# Altium schematic sheet Digital Output.SchDoc
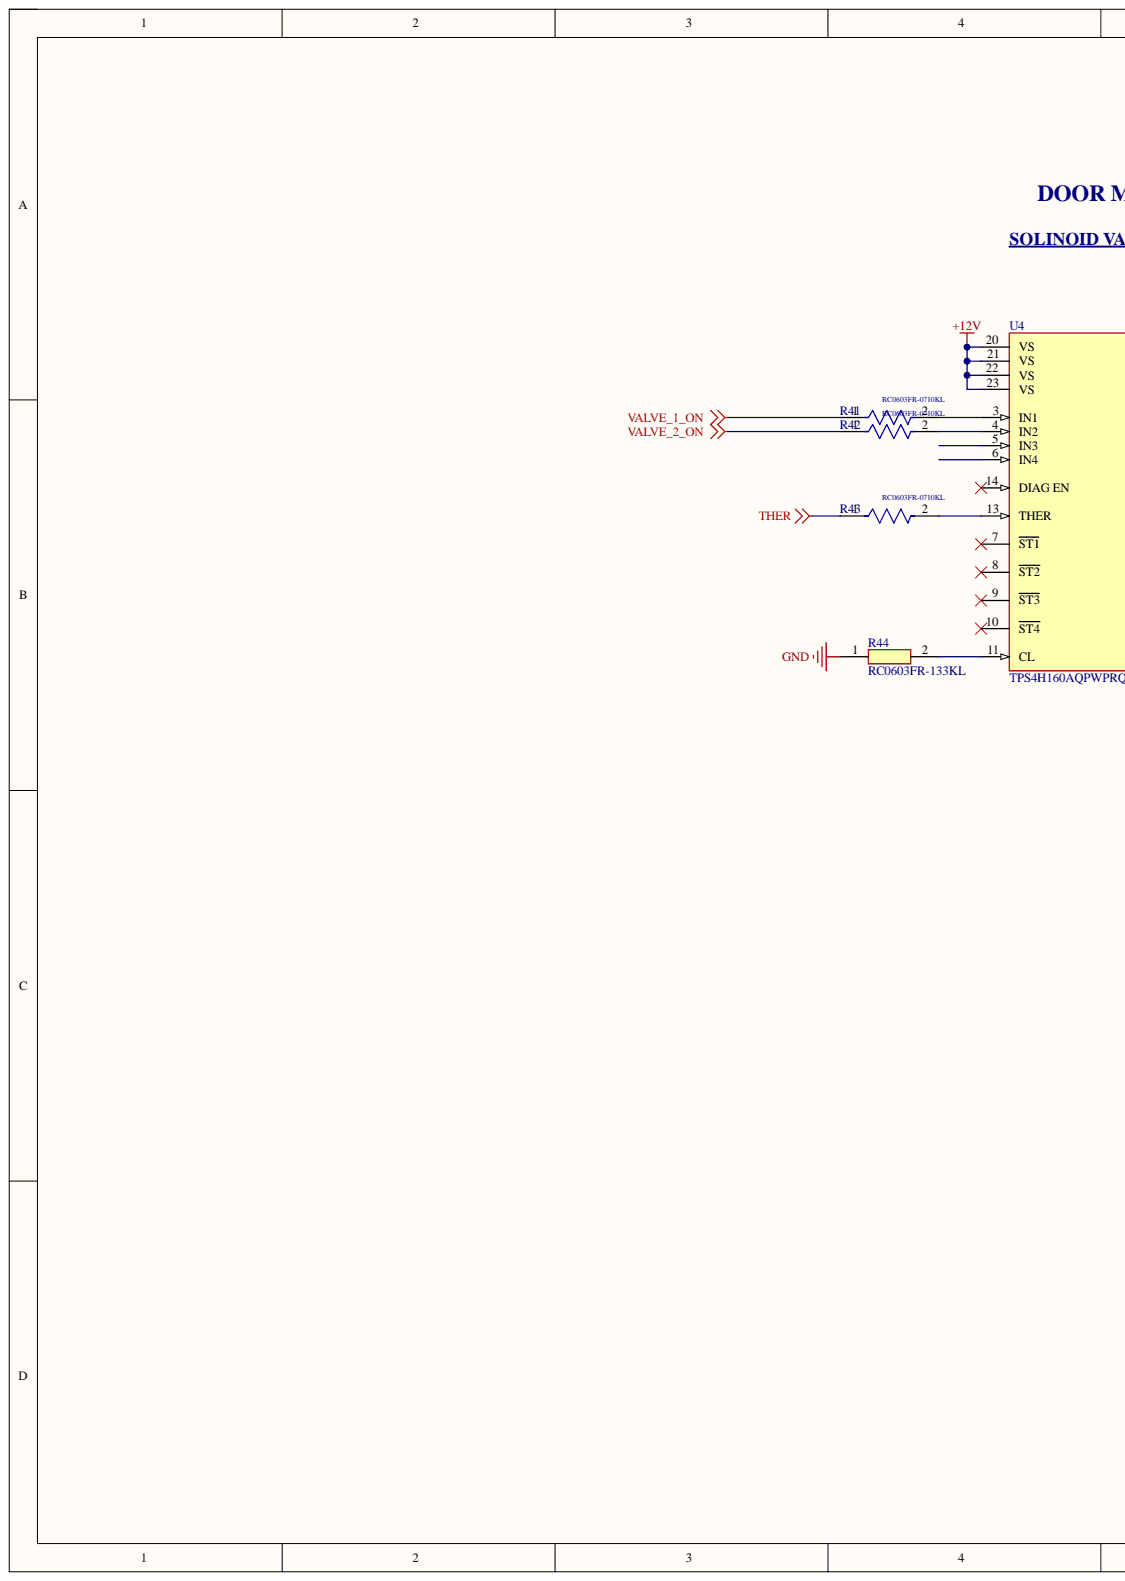
[diagram p0: Digital Output.SchDoc, left]
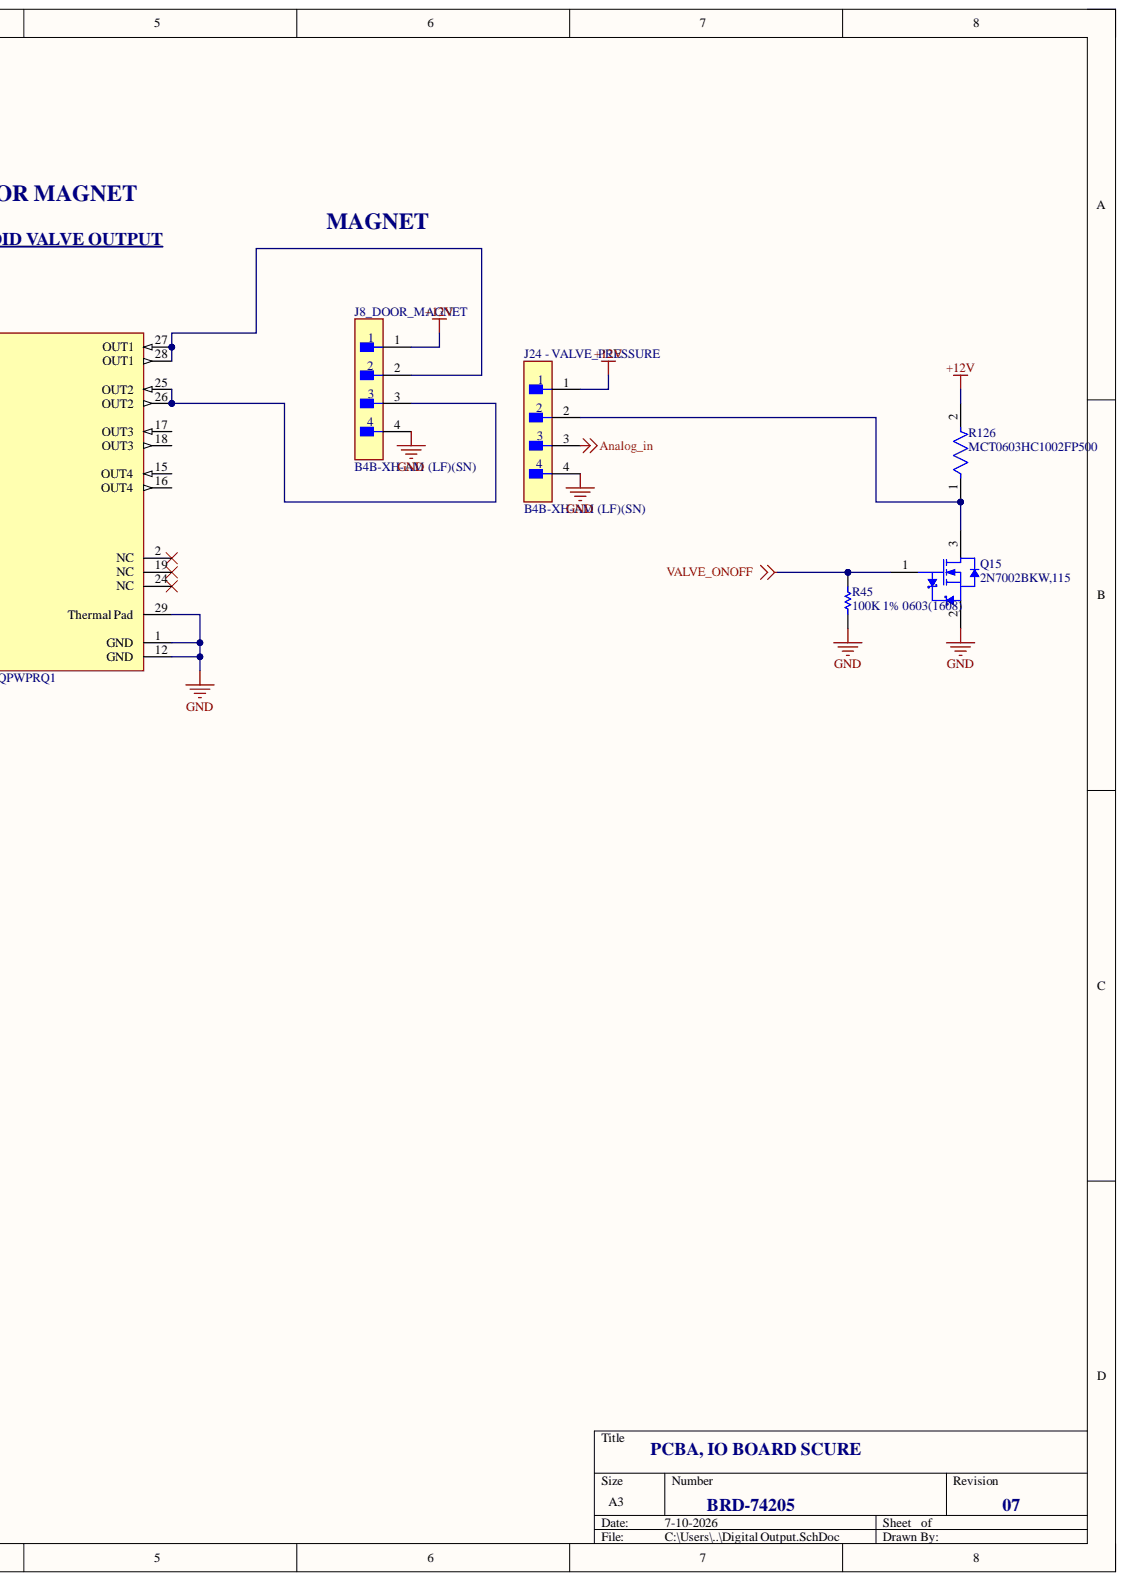
[diagram p1: Digital Output.SchDoc, right]

## Parts
J8_DOOR_MAGNET  B4B-XH-AM (LF)(SN)  [FP-B4B-XH-AM_LF_SN-MFG]
J24_-_VALVE_PRESSURE  B4B-XH-AM (LF)(SN)  [FP-B4B-XH-AM_LF_SN-MFG]
Q15  2N7002BKW,115  [NXP-SOT323_N]
R41  RC0603FR-0710KL  [FP-RC0603-0_55-IPC_C]
R42  RC0603FR-0710KL  [FP-RC0603-0_55-IPC_C]
R43  RC0603FR-0710KL  [FP-RC0603-0_55-IPC_C]
R44  RC0603FR-133KL  [RESC1608X55N]
R45  100K 1% 0603(1608)  [RESC0603(1608)_L]
R126  MCT0603HC1002FP500  [FP-MCT0603-IPC_B]
U4  TPS4H160AQPWPRQ1  [FP-PWP0028C-MFG]

## Nets
+12V: J8_DOOR_MAGNET.1 J24_-_VALVE_PRESSURE.1 R126.2 U4.20(VS) U4.21(VS) U4.22(VS) U4.23(VS)
Analog_in: J24_-_VALVE_PRESSURE.3
GND: J8_DOOR_MAGNET.4 J24_-_VALVE_PRESSURE.4 Q15.2 R44.1 R45.1 U4.1(GND) U4.12(GND) U4.29(Thermal_Pad)
THER: R43.1
VALVE_1_ON: R41.1
VALVE_2_ON: R42.1
VALVE_ONOFF: Q15.1 R45.2
NetJ8_DOOR_MAGNET_2: J8_DOOR_MAGNET.2 U4.27(OUT1) U4.28(OUT1)
NetJ8_DOOR_MAGNET_3: J8_DOOR_MAGNET.3 U4.25(OUT2) U4.26(OUT2)
NetJ24 - VALVE_PRESSURE_2: J24_-_VALVE_PRESSURE.2 Q15.3 R126.1
NetR41_2: R41.2 U4.3(IN1)
NetR42_2: R42.2 U4.4(IN2)
NetR43_2: R43.2 U4.13(THER)
NetR44_2: R44.2 U4.11(CL)

Not connected: U4 2(NC) 5(IN3) 6(IN4) 7(ST1) 8(ST2) 9(ST3) 10(ST4) 14(DIAG_EN) 15(OUT4) 16(OUT4) 17(OUT3) 18(OUT3) 19(NC) 24(NC)

## Library data of the parts
J8_DOOR_MAGNET J24_-_VALVE_PRESSURE = CMP-17439-000117-1: CONN HEADER VERT 4POS 2.5MM; Contact Resistance: 20mR; Gender: Male; Mated Stacking Heights: 9.8mm; Max Current Rating: 3A; Max Voltage Rating (AC): 250V; Number of Positions: 4; Color: Green; Component Kind: Standard; Connector Type: Header; Contact Finish - Mating: TIN; Contact Material: Brass; Contact Plating: Copper; Contact Shape: Square; Contact Type: Male Pin; Depth: 5.75mm; Fastening Type: Detent; Flammability Rating: UL94 V-0; Housing Color: White; Housing Material: Polyamide; Insulation Color: Natural; Insulation Material: Nylon; Lead Free: Lead Free; Length: 12.4mm; Library Name: Altium Content Vault; Max Operating Temperature: 85°C; Min Operating Temperature: -25°C; Mount: Through Hole; Number of Pins: 4; Number of Rows: 1; Orientation: Straight; Packaging: Bulk; Pitch: 2.5mm; Series: XH; Termination: Solder; Voltage Rating: 250V
Q15 = CMP-1259-00106-1: N-Channel Trench MOSFET, 60 V, 0.31 A, -55 to 150 degC, 3-Pin SOT323, Tape and Reel; Manufacturer: NXP Semiconductors; Automotive Qualified: Y; Channel Type: N; ID [max] (A): 0.31; IDM [max] (A): 1.2; Packing: Tape & Reel; VDS [max] (V): 60; VGSth [typ] (V): 1.6; Code_JEITA: SC-70; Component Kind: Standard; ComponentLink1Description: Manufacturer URL; ComponentLink1URL: http://www.nxp.com/; ComponentLink2Description: Datasheet; ComponentLink2URL: http://www.nxp.com/documents/data_sheet/2N7002BKW.pdf; ComponentLink3Description: Package Specification; ComponentLink3URL: http://www.nxp.com/documents/outline_drawing/sot323_po.pdf; DatasheetVersion: Rev. 1; Library Name: Altium Content Vault; Mounting Technology: Surface Mount; PackageDescription: 3-Pin Small Outline Transistor Package, Body 2 x 1.25 mm, Pitch 0.65 mm; PackageReference: SOT323; PackageVersion: 06-03-16, 03/2006; PartNumber: 2N7002BKW,115
R41-R43 = CMP-2100-03664-2: RES 10K OHM 1% 1/10W 0603; Max Power Dissipation: 100mW; Resistance: 10kR; Temperature Coefficient: 100ppm/°C; Voltage Rating: 75V; Voltage Rating (DC): 50V; Case Code (Imperial): 0603; Case Code (Metric): 1608; Case/Package: 0603; Component Kind: Standard; Construction: Rectangular; Depth: 0.8mm; Features: Moisture Resistant; Height: 0.45mm; Lead Free: Lead Free; Lead Pitch: 0.3mm; Length: 1.6mm; Library Name: Altium Content Vault; Max Operating Temperature: 155°C; Military Standard: Not; Min Operating Temperature: -55°C; Number of Pins: 2; Number of Terminations: 2; Packaging: Tape and Reel; Power Rating: 100mW; Resistance Tolerance: 1%; Resistor Type: Thick Film; RoHS Compliant: Yes; Series: RC; Size Code: 0603; Termination: SMD/SMT; Tolerance: 1%; Weight: 7.1E-05oz; Width: 0.8mm
R44 = RC0603FR-133KL: Resistor; Manufacturer_Name: KEMET; Component Kind: Standard; Datasheet Link: http://www.yageo.com/documents/recent/PYu-RC0603_51_RoHS_L_v5.pdf; Height: 0.55mm; Library Name: SamacSys.SchLib; Manufacturer_Part_Number: RC0603FR-133KL; Mouser Part Number: 603-RC0603FR-133KL; Mouser Price/Stock: https://www.mouser.co.uk/ProductDetail/YAGEO/RC0603FR-133KL?qs=IuGqVx9wL0Kf3sfMECqRww%3D%3D
R45 = CMP-1012-00736-1: 100K 0.1W 1% 0603 (1608 Metric)  SMD; Case-EIA: 0603; Case-Metric: 1608; Value: 100000.00 Ohm; Component Kind: Standard; Library Name: Altium Content Vault; Power: 0.1 W; Technology: SMT; Tolerance: 1 %
R126 = CMP-02407-001090-1: RES SMD 100 OHM 1% 1/8W 0603; Component Kind: Standard; Library Name: Altium Content Vault
U4 = CMP-04912-000024-1: IC POWER SWITCH N-CHAN 28HTSSOP; Manufacturer: Texas Instruments; Automotive Grade: Grade 1; Max Junction Temp: +150°C; Max Supply Voltage: 40V; Min Supply Voltage: 4V; Nominal Supply Current: 8mA; Number Of Drivers: 1; Number Of Outputs: 4; Output Current: 2.5A; Output Voltage: 2-6.5V; Standoff Height: 0.05mm; Turn Off Delay Time: 50us; Ambient Temperature Range High: +125°C; Ambient Temperature Range Low: -40°C; Automotive: Yes; Category: IC; Component Kind: Standard; ComponentLink2Description: Datasheet; ComponentLink2URL: http://www.ti.com/lit/ds/symlink/tps4h160-q1.pdf; Device Class L1: Integrated Circuits (ICs); Device Class L2: Power Management ICs; Device Class L3: Gate Drivers; Digikey Description: IC POWER SWITCH N-CHAN 28HTSSOP; Height: 1.2mm; Ipc Land Pattern Name: SOP65P640X120-28; Lead Free: Yes; Library Name: Altium Content Vault; Manufacturer Part Number: TPS4H160AQPWPRQ1; Octopart: https://octopart.com/tps4h160aqpwprq1-texas+instruments-70498894; Package: PWP0028C; RoHS: TRUE; Temperature: -40°C to +125°C; Temperature Range High: +125°C; Temperature Range Low: -40°C; Turn On Delay Time: 50us
